# Altium schematic sheet magnets.SchDoc
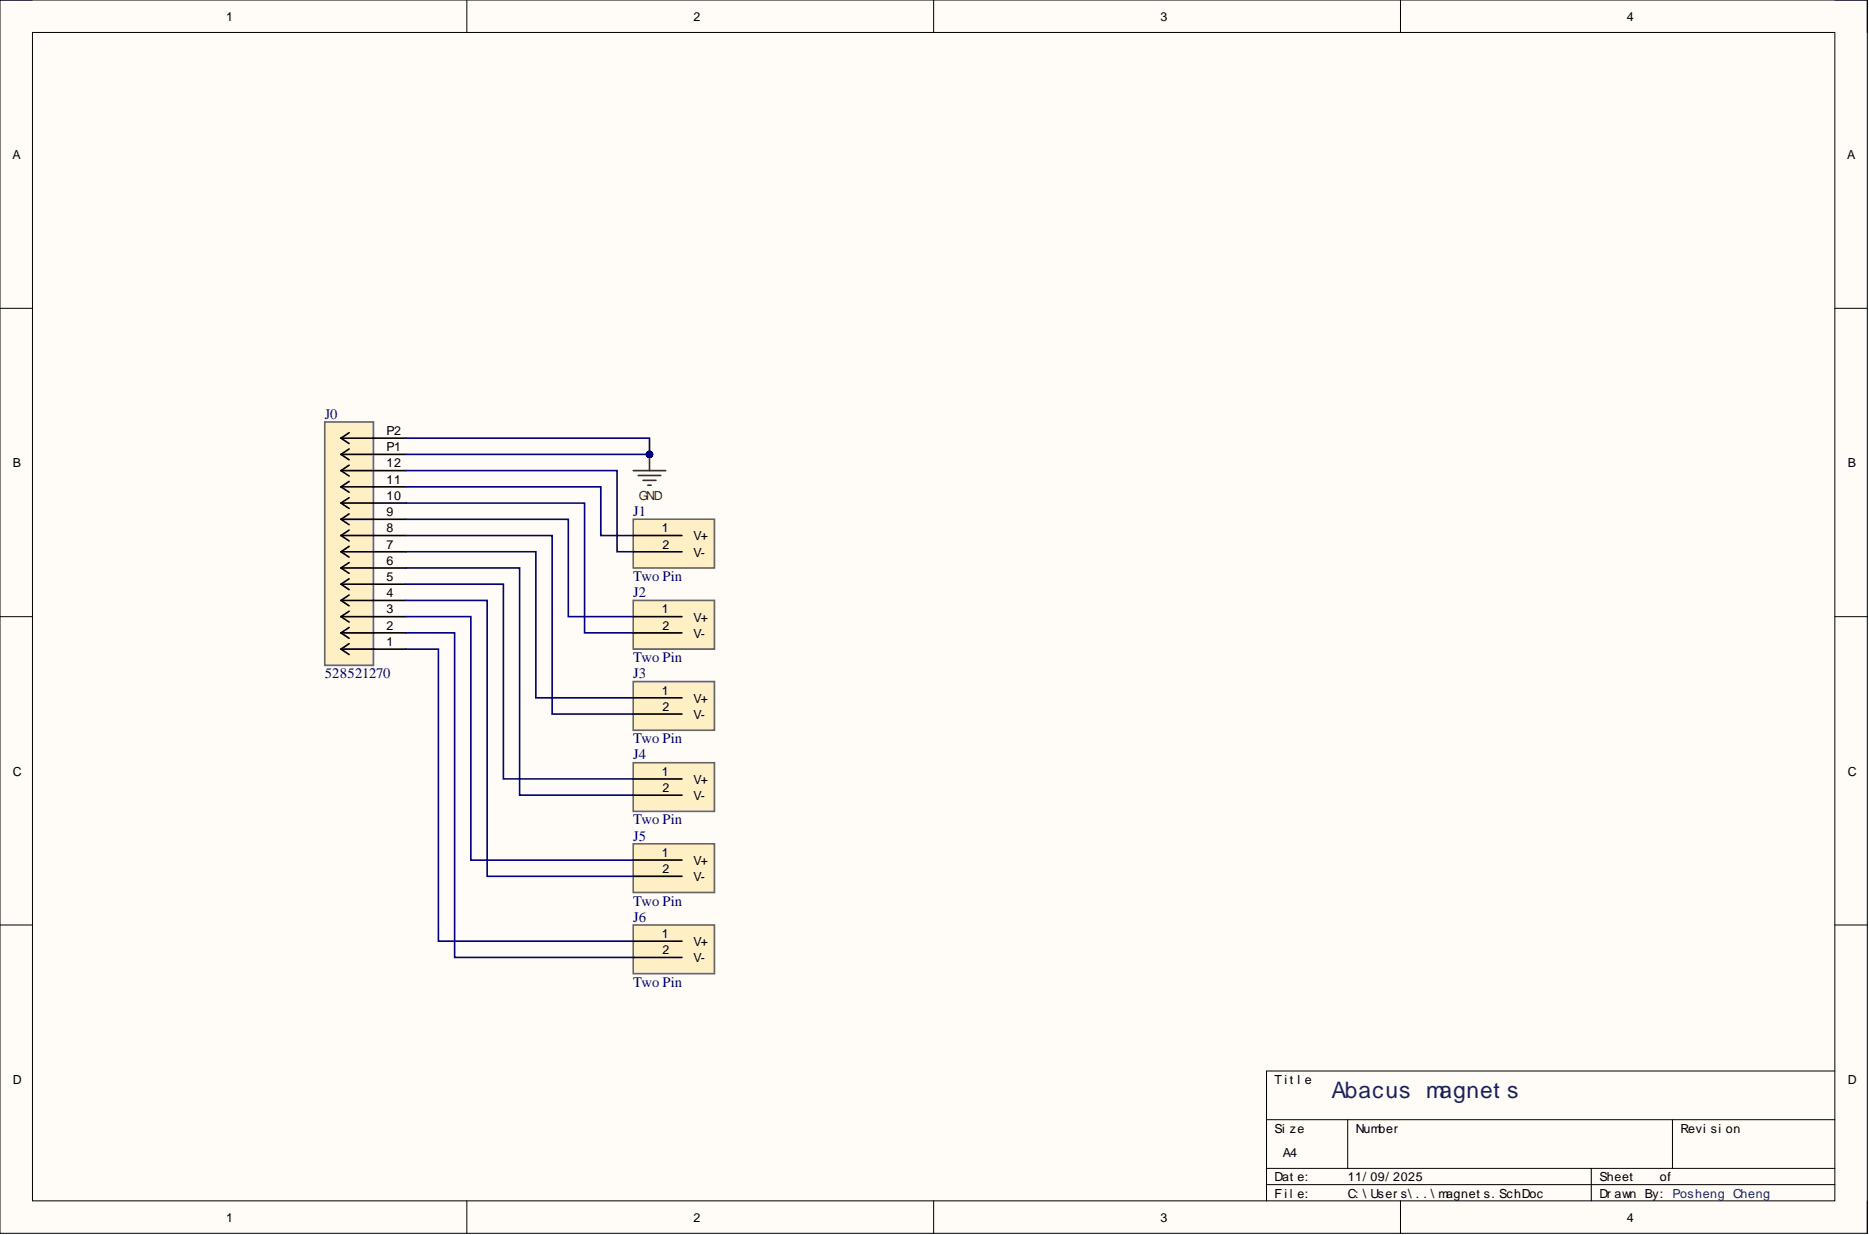
[diagram p0: magnets.SchDoc]

## Parts
J0  528521270  [528521270]
J1  Two Pin  [TWO_PIN]
J2  Two Pin  [TWO_PIN]
J3  Two Pin  [TWO_PIN]
J4  Two Pin  [TWO_PIN]
J5  Two Pin  [TWO_PIN]
J6  Two Pin  [TWO_PIN]

## Nets
GND: J0.P1 J0.P2
NetJ0_1: J0.1 J6.1
NetJ0_2: J0.2 J6.2
NetJ0_3: J0.3 J5.1
NetJ0_4: J0.4 J5.2
NetJ0_5: J0.5 J4.1
NetJ0_6: J0.6 J4.2
NetJ0_7: J0.7 J3.1
NetJ0_8: J0.8 J3.2
NetJ0_9: J0.9 J2.1
NetJ0_10: J0.10 J2.2
NetJ0_11: J0.11 J1.1
NetJ0_12: J0.12 J1.2

## Library data of the parts
J0 = 528521270: Easy-On FFC/FPC Connector, 1.00mm Pitch, Surface Mount, Right-Angle, Non-ZIF, Bottom Contact Style, 12 Circuits; Manufacturer_Name: Molex Connector Corporation; Component Kind: Standard; Library Name: 528521270.SchLib; Manufacturer_Part_Number: 528521270; RefDes: RefDes; System: Connector; Type: Type; Copyright: Copyright (C) 2025 Ultra Librarian. All rights reserved.
J1-J6 = two_pin: Component Kind: Standard; Library Name: twopin.SchLib
